# Altium schematic sheet VoltageSense.SchDoc
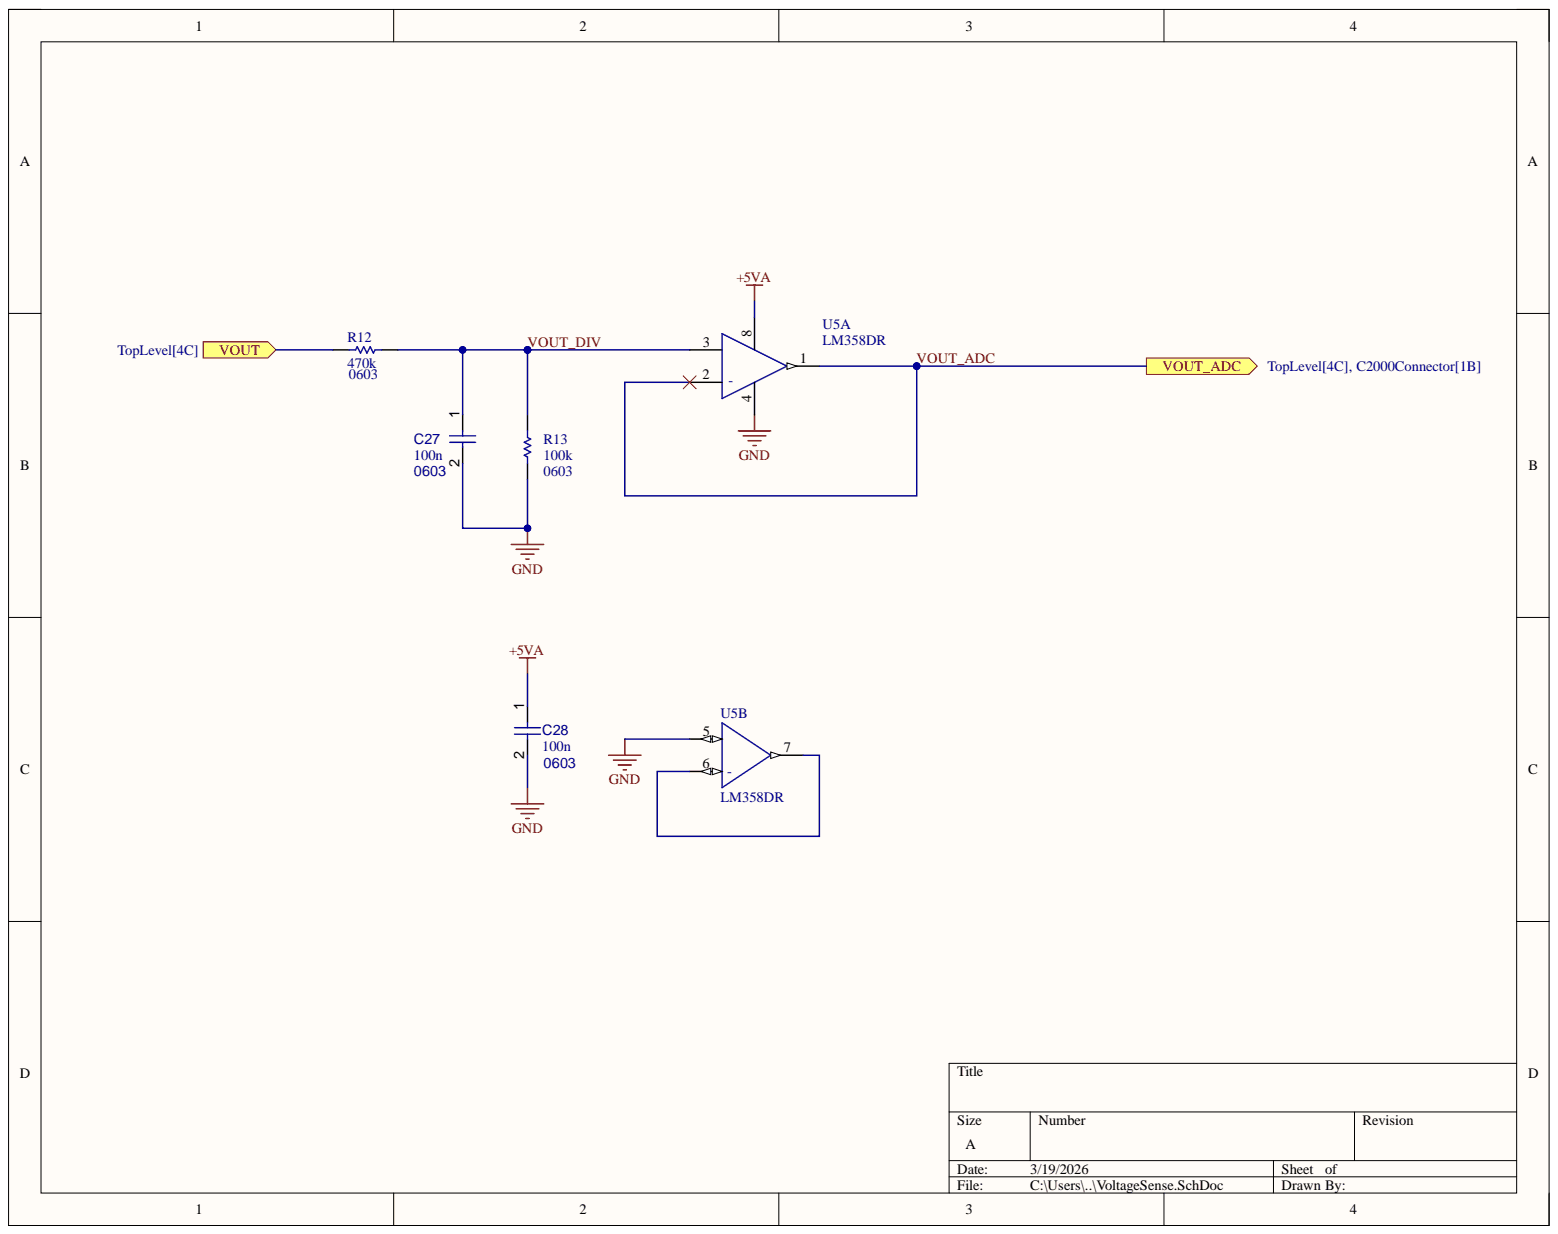
[diagram p0: VoltageSense.SchDoc]

## Parts
C27  0603  value 100n  [CAPC1608X90X40NL10T20]
C28  0603  value 100n  [CAPC1608X90X40NL10T20]
R12  0603  Reistance: 470k  [RESC1609X55X30ML10T20]
R13  0603  Reistance: 100k  [RESC1609X55X30ML10T20]
U5  LM358DR  [FP-D0008A-MFG]  (parts A,B)

## Ports
VOUT, VOUT_ADC

## Nets
+5VA: C28.1 U5.8
GND: C27.2 C28.2 R13.2 U5.4 U5.5
VOUT: R12.1 ; port VOUT
VOUT_ADC: U5.1 U5.2(-) ; port VOUT_ADC
VOUT_DIV: C27.1 R12.2 R13.1 U5.3
NetU5_6: U5.6(-) U5.7

## Library data of the parts
C27 C28 = CMP-001-00017-6: Chip Capacitor, 100 nF, +/- 10%, 50 V, 0603 (1608 Metric); Capacitor Type: Ceramic; Voltage Rating: 50V; Case/Package: 0603; Component Kind: Standard; Max Operating Temperature: 125°C; Min Operating Temperature: -55°C; Mounting Technology: SM; Pins: 2; RoHS Compliant: True; Tolerance: 10%; Library Name: Ishaan Gupta
R12 R13 = CMP-009-000010-1: RES 100K OHM 0.1% 0.21W 0603; Manufacturer: Vishay Dale; Manufacturer Part Number: TNPW0603100KBEEA; Component Kind: Standard; Component Type: Standard; Design Item ID: CMP-2000-03685-1; Part Number: TNPW0603100KBEEA; Pin Count: 2; Size: 0603; Source: PV Buck v2.SCHLIB; Tolerance: 0.1%; Library Name: Ishaan Gupta
U5 = CMP-1685-00009-2: IC OPAMP GP 2 CIRCUIT 8SOIC; Bandwidth: 700kHz; Common Mode Rejection Ratio: 65dB; Dual Supply Voltage: 9V; Input Bias Current: 250nA; Input Offset Voltage (Vos): 7mV; Max Dual Supply Voltage: 16V; Max Supply Voltage: 32V; Min Dual Supply Voltage: 1.5V; Min Supply Voltage: 3V; Nominal Supply Current: 1.2mA; Number of Amplifiers: 2; Operating Supply Current: 1mA; Output Current: 30mA; Slew Rate: 0.3V/µs; Voltage Gain: 100dB; Case/Package: SOIC; Component Kind: Standard; Contact Plating: Gold; Gain Bandwidth Product: 700kHz; Height: 1.58mm; Lead Free: Lead Free; Length: 4.9mm; Library Name: Altium Content Vault; Max Operating Temperature: 70°C; Min Operating Temperature: 0°C; Mount: Surface Mount; Number of Channels: 2; Number of Circuits: 2; Number of Elements: 2; Number of Pins: 8; Operating Supply Voltage: 16V; Output Current per Channel: 30mA; Package Quantity: 2500; Packaging: Tape and Reel; Power Supply Rejection Ratio (PSRR): 65dB; Radiation Hardening: No; REACH SVHC: No SVHC; Unity Gain Bandwidth Product: 700kHz; Width: 3.91mm
